# Altium schematic sheet DualBuck.SchDoc(DualBuck)
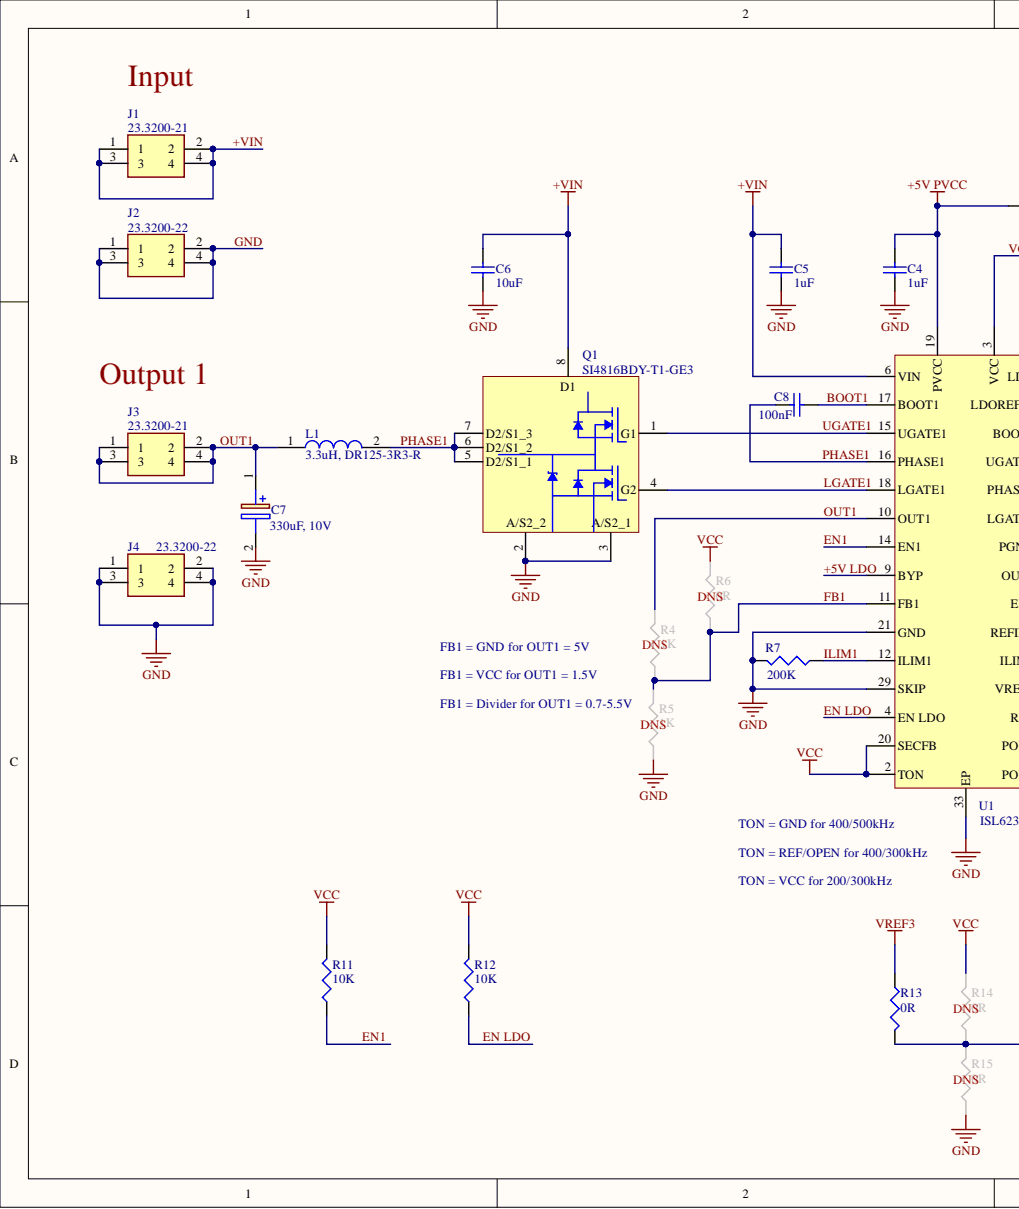
[diagram p0: DualBuck.SchDoc, left]
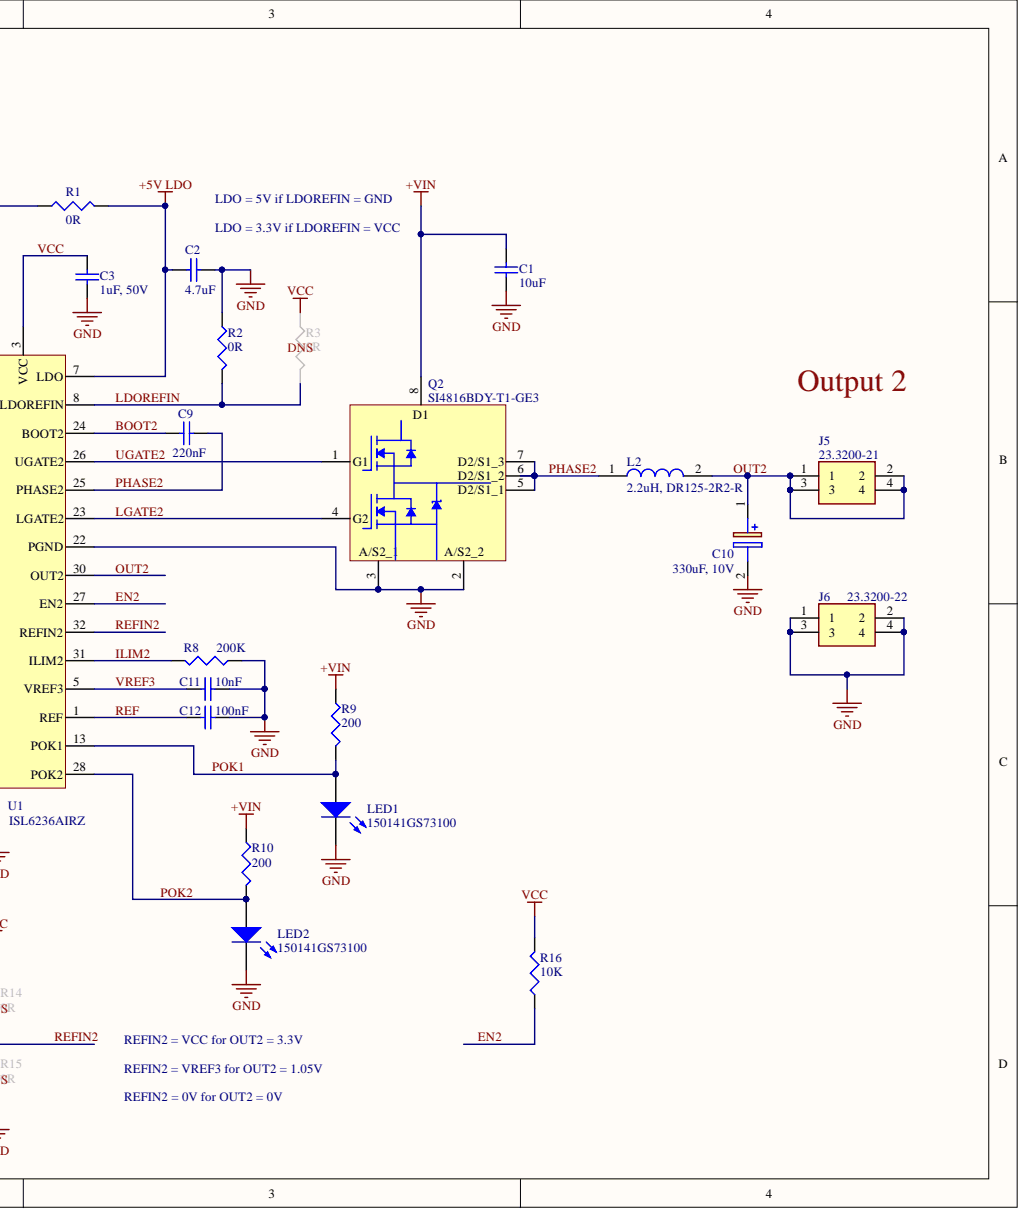
[diagram p1: DualBuck.SchDoc, right]

## Parts
C1  value 10uF  [C1210]
C2  value 4.7uF  [C1206]
C3  value 1uF, 50V  [C0805]
C4  value 1uF  [C0805]
C5  value 1uF  [C0805]
C6  value 10uF  [C1210]
C7  330uF, 10V  [CAPPM7343X430N]
C8  value 100nF  [C0805]
C9  value 220nF  [C0805]
C10  330uF, 10V  [CAPPM7343X430N]
C11  value 10nF  [C0805]
C12  value 100nF  [C0805]
J1  23.3200-21  [23320021]
J2  23.3200-22  [23320022]
J3  23.3200-21  [23320021]
J4  23.3200-22  [23320022]
J5  23.3200-21  [23320021]
J6  23.3200-22  [23320022]
L1  3.3uH, DR125-3R3-R  [INDPM125125X600N]
L2  2.2uH, DR125-2R2-R  [INDPM125125X600N]
LED1  150141GS73100  [3528]
LED2  150141GS73100  [3528]
Q1  SI4816BDY-T1-GE3  [SOT127P600X175-8N]
Q2  SI4816BDY-T1-GE3  [SOT127P600X175-8N]
R1  value 0R  [R0805]  (hidden comment: Res3)
R2  value 0R  [R0805]  (hidden comment: Res3)
R3  value 0R  [R0805]  (hidden comment: Res3)
R4  value 1K  [R0805]  (hidden comment: Res3)
R5  value 1K  [R0805]  (hidden comment: Res3)
R6  value 0R  [R0805]  (hidden comment: Res3)
R7  value 200K  [R0805]  (hidden comment: Res3)
R8  value 200K  [R0805]  (hidden comment: Res3)
R9  value 200  [R0805]  (hidden comment: Res3)
R10  value 200  [R0805]  (hidden comment: Res3)
R11  value 10K  [R0805]
R12  value 10K  [R0805]  (hidden comment: Res3)
R13  value 0R  [R0805]  (hidden comment: Res3)
R14  value 0R  [R0805]  (hidden comment: Res3)
R15  value 0R  [R0805]  (hidden comment: Res3)
R16  value 10K  [R0805]  (hidden comment: Res3)
U1  ISL6236AIRZ  [QFN50P500X500X100-33N]

## Nets
+5V PVCC: C4.2 R1.1 U1.19(PVCC)
+5V LDO: C2.2 R1.2 U1.7(LDO) U1.9(BYP)
+VIN: C1.2 C5.2 C6.2 J1.1 J1.2 J1.3 J1.4 Q1.8(D1) Q2.8(D1) R9.1 R10.1 U1.6(VIN)
BOOT1: C8.1 U1.17(BOOT1)
BOOT2: C9.2 U1.24(BOOT2)
EN1: R11.1 U1.14(EN1)
EN2: R16.1 U1.27(EN2)
EN LDO: R12.1 U1.4(EN_LDO)
FB1: R4.1 R5.2 R6.1 U1.11(FB1)
GND: C1.1 C2.1 C3.1 C4.1 C5.1 C6.1 C7.2 C10.2 C11.1 C12.1 J2.1 J2.2 J2.3 J2.4 J4.1 J4.2 J4.3 J4.4 J6.1 J6.2 J6.3 J6.4 LED1.1 LED2.1 Q1.2 Q1.3 Q2.2 Q2.3 R2.1 R5.1 R7.2 R8.1 R15.2 U1.21(GND) U1.22(PGND) U1.29(SKIP) U1.33(EP)
ILIM1: R7.1 U1.12(ILIM1)
ILIM2: R8.2 U1.31(ILIM2)
LDOREFIN: R2.2 R3.2 U1.8(LDOREFIN)
LGATE1: Q1.4(G2) U1.18(LGATE1)
LGATE2: Q2.4(G2) U1.23(LGATE2)
OUT1: C7.1 J3.1 J3.2 J3.3 J3.4 L1.1 R4.2 U1.10(OUT1)
OUT2: C10.1 J5.1 J5.2 J5.3 J5.4 L2.2 U1.30(OUT2)
PHASE1: C8.2 L1.2 Q1.5(D2/S1_1) Q1.6(D2/S1_2) Q1.7(D2/S1_3) U1.16(PHASE1)
PHASE2: C9.1 L2.1 Q2.5(D2/S1_1) Q2.6(D2/S1_2) Q2.7(D2/S1_3) U1.25(PHASE2)
POK1: LED1.2 R9.2 U1.13(POK1)
POK2: LED2.2 R10.2 U1.28(POK2)
REF: C12.2 U1.1(REF)
REFIN2: R13.2 R14.2 R15.1 U1.32(REFIN2)
UGATE1: Q1.1(G1) U1.15(UGATE1)
UGATE2: Q2.1(G1) U1.26(UGATE2)
VCC: C3.2 R3.1 R6.2 R11.2 R12.2 R14.1 R16.2 U1.2(TON) U1.3(VCC) U1.20(SECFB)
VREF3: C11.2 R13.1 U1.5(VREF3)

## Library data of the parts
C1-C6 C8 C9 C11 C12 = Cap: Capacitor; Simulation: CAP; Signal Integrity: Cap; Published: 8-Jun-2000; PackageReference: RAD-0.3; PackageDescription: Radial Cap, Thru-Hole; 2 Leads; 0.3 in Pin Spacing; Library Name: Miscellaneous Devices.IntLib; LatestRevisionNote: Re-released for DXP Platform.; LatestRevisionDate: 17-Jul-2002; Component Kind: Standard; Publisher: Altium Limited
C7 C10 = T530X337M010ATE004: Capacitor Polarised; Manufacturer_Name: Kemet; RS Price/Stock: https://uk.rs-online.com/web/p/products/6925817; RS Part Number: 6925817; Mouser Price/Stock: https://www.mouser.com/Search/Refine.aspx?Keyword=80-T530X337M010ATE4; Mouser Part Number: 80-T530X337M010ATE4; Manufacturer_Part_Number: T530X337M010ATE004; Height: 4.3mm; Datasheet Link: http://capacitoredge.kemet.com/capedge2/DataSheet?pn=T530X337M010ATE004; Component Kind: Standard
J1 J3 J5 = 23.3200-21: Connector; Manufacturer_Name: Multi Contact; RS Price/Stock: http://uk.rs-online.com/web/p/products/4225051; RS Part Number: 4225051; Manufacturer_Part_Number: 23.3200-21; Height: 11mm; Datasheet Link: https://docs-emea.rs-online.com/webdocs/025b/0900766b8025b994.pdf; Component Kind: Standard
J2 J4 J6 = 23.3200-22: Connector; Manufacturer_Name: Multi Contact; RS Price/Stock: http://uk.rs-online.com/web/p/products/4225067; RS Part Number: 4225067; Manufacturer_Part_Number: 23.3200-22; Height: 14.9mm; Datasheet Link: http://www.farnell.com/datasheets/2827925.pdf?_ga=2.233889822.1621494036.1565215269-167411096.1502103357; Component Kind: Standard
L1 = DR125-3R3-R: Inductor; Manufacturer_Name: Cooper Bussmann; RS Price/Stock: https://uk.rs-online.com/web/p/products/7700974; RS Part Number: 7700974; Manufacturer_Part_Number: DR125-3R3-R; Height: 6mm; Datasheet Link: http://www.cooperindustries.com/content/dam/public/bussmann/Electronics/Resources/product-datasheets/Bus_Elx_DS_4315_DR_Series.pdf; Component Kind: Standard
L2 = DR125-2R2-R: Inductor; Manufacturer_Name: Cooper Bussmann; RS Price/Stock: https://uk.rs-online.com/web/p/products/7700968; RS Part Number: 7700968; Manufacturer_Part_Number: DR125-2R2-R; Height: 6mm; Datasheet Link: http://www1.cooperbussmann.com/pdf/4a32919a-7470-4ae0-be45-b59e3a1351ef.pdf; Component Kind: Standard
LED1 LED2 = 150141GS73100: SMD mono-color Top LED, WL-SMTW, Green; PartNumber: 150141GS73100; PackageReference: 3528; Library Name: WL-SMTW SMD TOP LED mono-color waterclear.IntLib; ComponentLink2URL: http://katalog.we-online.com/led/datasheet/150141GS73100.pdf; ComponentLink2Description: Datasheet; ComponentLink1URL: http://www.we-online.com; ComponentLink1Description: Manufacturer URL; Component Kind: Standard; Color: Green
Q1 Q2 = SI4816BDY-T1-GE3: Integrated Circuit; Library Name: DualBuck.SchLib; Component Kind: Standard
R1-R16 = Res3: Resistor; Simulation: RESISTOR; Signal Integrity: Res; Published: 8-Jun-2000; PackageReference: J1-0603; PackageDescription: Chip Resistor; Library Name: Miscellaneous Devices.IntLib; LatestRevisionNote: IPC-7351 Footprint Added.; LatestRevisionDate: 29-May-2009; Component Kind: Standard; Publisher: Altium Limited
U1 = ISL6236AIRZ: Integrated Circuit; Library Name: DualBuck.SchLib; Component Kind: Standard
